# Altium schematic sheet POWER.SchDoc(POWER)
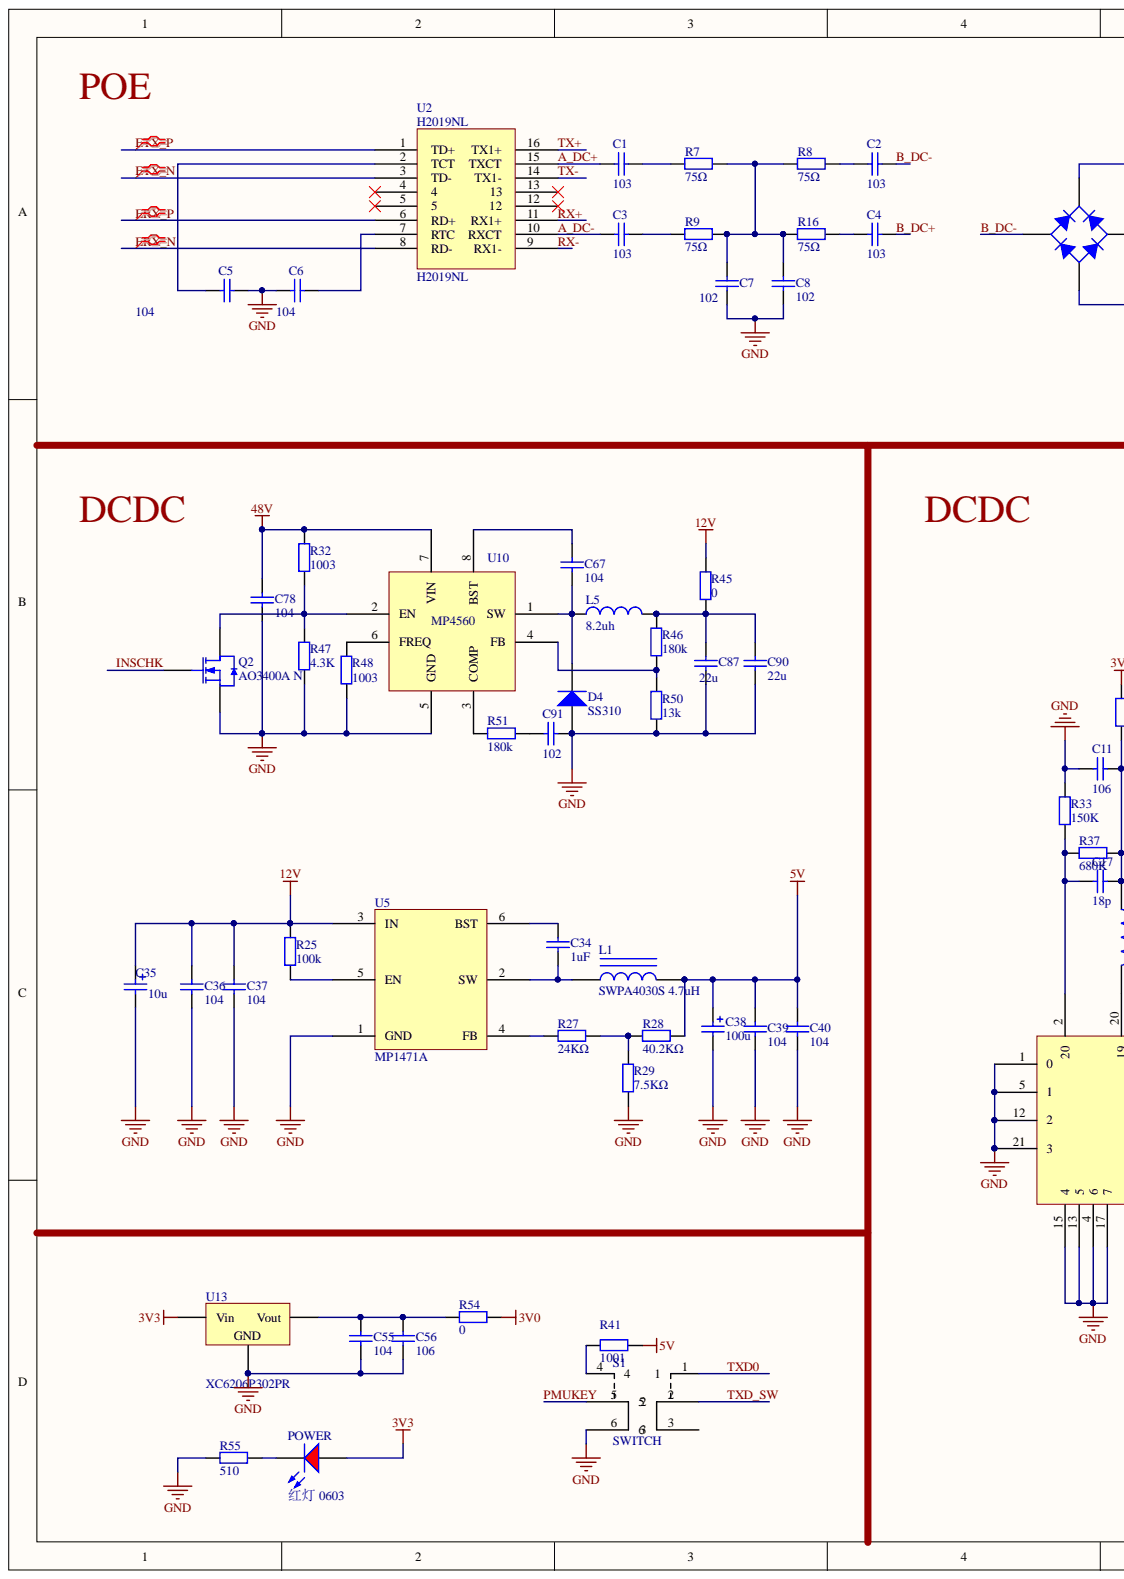
[diagram p0: POWER.SchDoc, left]
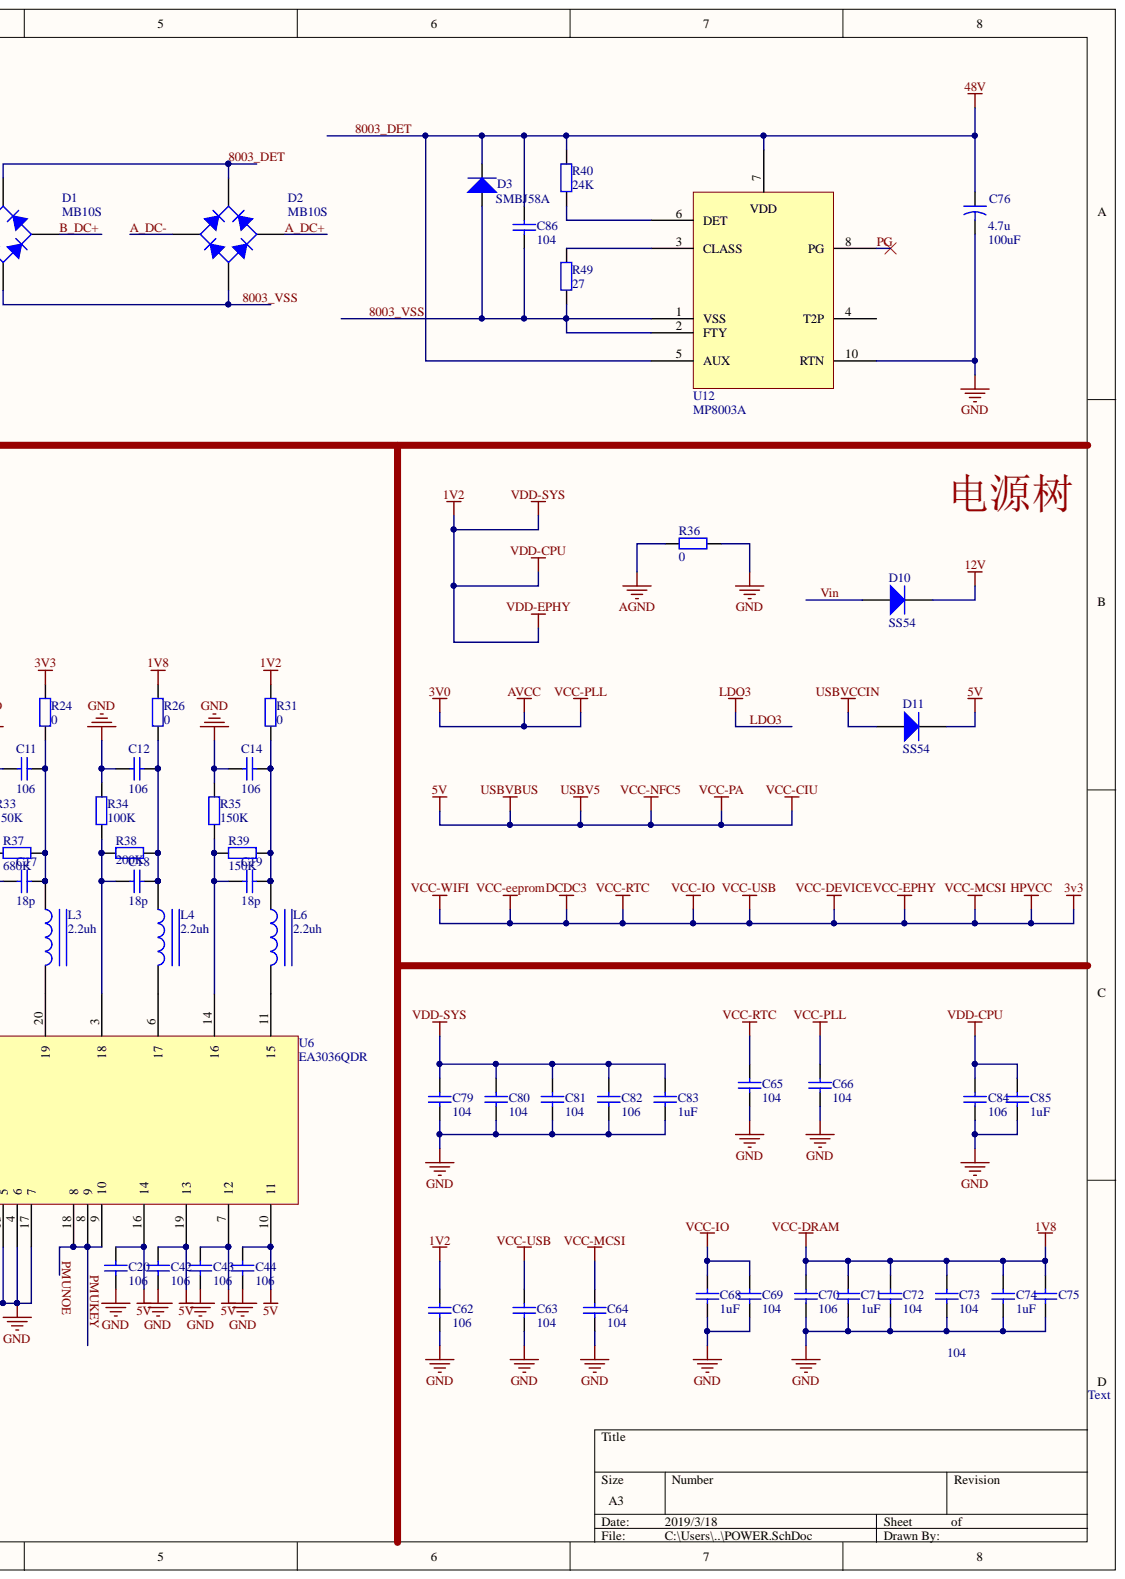
[diagram p1: POWER.SchDoc, right]

## Parts
C1  103  [0402_C]
C2  103  [0402_C]
C3  103  [0402_C]
C4  103  [0402_C]
C5  104  [0402_C]
C6  104  [0402_C]
C7  102  [0402_C]
C8  102  [0402_C]
C11  106  [0603_C]
C12  106  [0603_C]
C14  106  [0603_C]
C17  18p  [0402_C]
C18  18p  [0402_C]
C19  18p  [0402_C]
C20  106  [0603_C]
C34  1uF  [0402_C]
C35  10u  [SMD,4x5.4mm]
C36  104  [0402_C]
C37  104  [0402_C]
C38  100u  [SMD,5x5.4]
C39  104  [0402_C]
C40  104  [0402_C]
C42  106  [0603_C]
C43  106  [0603_C]
C44  106  [0603_C]
C55  104  [0402_C]
C56  106  [0603_C]
C62  106  [0402_C]
C63  104  [0402_C]
C64  104  [0402_C]
C65  104  [0402_C]
C66  104  [0402_C]
C67  104  [0402_C]
C68  1uF  [0402_C]
C69  104  [0402_C]
C70  106  [0402_C]
C71  1uF  [0402_C]
C72  104  [0402_C]
C73  104  [0402_C]
C74  1uF  [0402_C]
C75  104  [0402_C]  (value not drawn)
C76  4.7u  value 100uF  [CAPAE7066X54M]
C78  104  [1206_C]
C79  104  [0402_C]
C80  104  [0402_C]
C81  104  [0402_C]
C82  106  [0402_C]
C83  1uF  [0402_C]
C84  106  [0402_C]
C85  1uF  [0402_C]
C86  104  [C1206]
C87  22u  [0805_C]
C90  22u  [0805_C]
C91  102  [0402_C]
D1  MB10S  [MBS]
D2  MB10S  [MBS]
D3  SMBJ58A  [SMB(DO-214AA)_S1]
D4  SS310  [SMA(DO-214AC)_S1]
D10  SS54  [SMA(DO-214AC)_S1]
D11  SS54  [SMA(DO-214AC)_S1]
L1  SWPA4030S 4.7uH  [INDP4040X30N]
L3  2.2uh  [0806]
L4  2.2uh  [0806]
L5  value 8.2uh  [SWRH8040B]  (hidden comment: Inductor 8.2uh)
L6  2.2uh  [0806]
POWER  红灯 0603  Package: 0603  [0603_LED_S1]
Q2  AO3400A N  [SOT-23-3L]
R7  75Ω  [0402_R]
R8  75Ω  [0402_R]
R9  75Ω  [0402_R]
R16  75Ω  [0402_R]
R24  0  [0402_R]
R25  100k  [0402_R]
R26  0  [0402_R]
R27  24KΩ  [0402_R]
R28  40.2KΩ  [0603_R]
R29  7.5KΩ  [0402_R]
R31  0  [0402_R]
R32  1003  [0402_R]
R33  150K  [0402_R]
R34  100K  [0402_R]
R35  150K  [0402_R]
R36  0  [0402_R]
R37  680K  [0603_R]
R38  200K  [0402_R]
R39  150K  [0402_R]
R40  24K  [0402_R]
R41  1001  [0402_R]
R45  0  [0402_R]
R46  180k  [0603_R]
R47  4.3K  [0603_R]
R48  1003  [0402_R]
R49  27  [0603_R]
R50  13k  [0603_R]
R51  180k  [0603_R]
R54  0  [0402_R]
R55  510  [0402_R]
S1  SWITCH  [switch]
U2  H2019NL  [NetTrans]
U5  MP1471A  [SOT-23-6]
U6  EA3036QDR  [QFN20_3X3]
U10  MP4560  [LC-SOIC-8_EP_150mil]
U12  MP8003A  [QFN-10_3x3x05P]
U13  XC6206P302PR  [SOT-23-3L]

## Nets
1V2: C62.1 C79.2 C80.2 C81.2 C82.2 C83.2 C84.2 C85.2 R31.2
1V8: C70.2 C71.2 C72.2 C73.2 C74.2 C75.2 R26.2
3V0: C66.2 R54.1
3V3: C63.2 C64.2 C65.2 C68.2 C69.2 POWER.A R24.2 U13.3
5V: C20.1 C38.1 C39.2 C40.2 C42.1 C43.1 C44.1 D11.2 L1.2 R28.2 R41.2 U6.7 U6.10 U6.16 U6.19
12V: C35.1 C36.2 C37.2 D10.2 R25.2 R45.2 U5.3(IN)
AGND: R36.2
GND: C5.1 C6.2 C7.1 C8.1 C11.1 C12.1 C14.1 C20.2 C35.2 C36.1 C37.1 C38.2 C39.1 C40.1 C42.2 C43.2 C44.2 C55.1 C56.1 C62.2 C63.1 C64.1 C65.1 C66.1 C68.1 C69.1 C70.1 C71.1 C72.1 C73.1 C74.1 C75.1 C76.2 C78.1 C79.1 C80.1 C81.1 C82.1 C83.1 C84.1 C85.1 C87.1 C90.1 C91.2 D4.1 Q2.2 R29.1 R33.2 R34.1 R35.2 R36.1 R47.1 R48.1 R50.1 R55.1 S1.6 U5.1(GND) U6.1 U6.4 U6.5 U6.12 U6.13 U6.15 U6.17 U6.21 U10.5(GND) U12.10(RTN) U13.1
USBVCCIN: D11.1
8003_DET: C76.1 C78.2 C86.2 D1.2 D2.2 D3.2 R32.2 R40.2 U10.7(VIN) U12.5(AUX) U12.7
8003_VSS: C86.1 D1.4 D2.4 D3.1 R49.1 U12.1(VSS) U12.2(FTY)
A_DC+: C1.1 D2.3 U2.15
A_DC-: C3.1 D2.1 U2.10
B_DC+: C4.2 D1.3
B_DC-: C2.2 D1.1
ERX_N: U2.8
ERX_P: U2.6
ETX_N: U2.3
ETX_P: U2.1
INSCHK: Q2.1
PG: U12.8(PG)
PMUKEY: S1.5 U6.8 U6.9 U6.18
RX+: U2.11
RX-: U2.9
TX+: U2.16
TX-: U2.14
TXD0: S1.1
TXD_SW: S1.2
Vin: D10.1
NetC1_2: C1.2 R7.1
NetC2_1: C2.1 R8.2
NetC3_2: C3.2 R9.1
NetC4_1: C4.1 R16.2
NetC5_2: C5.2 U2.2
NetC6_1: C6.1 U2.7
NetC7_2: C7.2 C8.2 R7.2 R8.1 R9.2 R16.1
NetC11_2: C11.2 C17.2 L3.1 R24.1 R37.2
NetC12_2: C12.2 C18.2 L4.1 R26.1 R38.2
NetC14_2: C14.2 C19.2 L6.1 R31.1 R39.2
NetC17_1: C17.1 R33.1 R37.1 U6.2
NetC18_1: C18.1 R34.2 R38.1 U6.3
NetC19_1: C19.1 R35.1 R39.1 U6.14
NetC34_1: C34.1 L1.1 U5.2(SW)
NetC34_2: C34.2 U5.6(BST)
NetC55_2: C55.2 C56.2 R54.2 U13.2
NetC67_1: C67.1 D4.2 L5.1 U10.1(SW)
NetC67_2: C67.2 U10.8(BST)
NetC87_2: C87.2 C90.2 L5.2 R45.1 R46.2
NetC91_1: C91.1 R51.2
NetL3_2: L3.2 U6.20
NetL4_2: L4.2 U6.6
NetL6_2: L6.2 U6.11
NetPOWER_K: POWER.K R55.2
NetQ2_3: Q2.3 R32.1 R47.2 U10.2(EN)
NetR25_1: R25.1 U5.5(EN)
NetR27_1: R27.1 U5.4(FB)
NetR27_2: R27.2 R28.1 R29.2
NetR40_1: R40.1 U12.6(DET)
NetR41_1: R41.1 S1.4
NetR46_1: R46.1 R50.2 U10.4(FB)
NetR48_2: R48.2 U10.6(FREQ)
NetR49_2: R49.2 U12.3(CLASS)
NetR51_1: R51.1 U10.3(COMP)
LDO3:

Not connected: S1 3; U2 4 5 12 13; U12 4(T2P)

## Library data of the parts
C1-C4 = 0603 10nF (103) 10% 50V: 贴片电容; SuppliersPartNumber: C57112; Supplier: LC; Package: 0603; Library Name: Cap.SchLib; ComponentLink1URL: http://www.szlcsc.com/product/details_58143.html; ComponentLink1Description: 供应商链接; Component Kind: Standard
C5 C6 C36 C37 C39 C40 C63-C67 C69 C72 C73 C75 C79-C81 = 0603 100nF (104) 10% 50V: 贴片电容; SuppliersPartNumber: C14663; Supplier: LC; Package: 0603; Library Name: Cap.SchLib; ComponentLink1URL: http://www.szlcsc.com/product/details_15331.html; ComponentLink1Description: 供应商链接; Component Kind: Standard
C7 C8 C91 = 0603 1nF (102) 10% 50V: 贴片电容; SuppliersPartNumber: C1588; Supplier: LC; Package: 0603; Library Name: Cap.SchLib; ComponentLink1URL: http://www.szlcsc.com/product/details_1940.html; ComponentLink1Description: 供应商链接; Component Kind: Standard
C11 C12 C14 C20 C42-C44 C56 C62 C70 C82 C84 = 0603 10uF (106) 10% 10V: 贴片电容; SuppliersPartNumber: C19702; Supplier: LC; Package: 0603; ComponentLink1URL: http://www.szlcsc.com/product/details_20411.html; ComponentLink1Description: 供应商链接; Component Kind: Standard
  C11 C12 C14 C20 C42-C44 C56: Library Name: Miscellaneous Devices LC.IntLib
  C62 C70 C82 C84: Library Name: Cap.SchLib
C17-C19 = 0402 18pF (180) 5% 50V: 贴片电容; SuppliersPartNumber: C1549; Supplier: LC; Package: 0402; Library Name: Miscellaneous Devices LC.IntLib; ComponentLink1URL: http://www.szlcsc.com/product/details_1901.html; ComponentLink1Description: 供应商链接; Component Kind: Standard
C34 C68 C71 C74 C83 C85 = 0603 1uF (105) 10% 50V: 贴片电容; SuppliersPartNumber: C15849; Supplier: LC; Package: 0603; Library Name: Cap.SchLib; ComponentLink1URL: http://www.szlcsc.com/product/details_16531.html; ComponentLink1Description: 供应商链接; Component Kind: Standard
C35 = 3216 10uF (106) 10% 16V: 贴片点解电容 CS1C100M-CRC54; SuppliersPartNumber: C7171; Supplier: LC; Package: 3216; Library Name: Cap.SchLib; ComponentLink1URL: http://www.szlcsc.com/product/details_7643.html; ComponentLink1Description: 供应商链接; Component Kind: Standard
C38 = 3528 100uF (107) 10% 6.3V: 贴片点解电容 CS0J101M-CRD54; SuppliersPartNumber: C16133; Supplier: LC; Package: 3528; Library Name: Cap.SchLib; ComponentLink1URL: http://www.szlcsc.com/product/details_16815.html; ComponentLink1Description: 供应商链接; Component Kind: Standard
C55 = 0402 100nF (104) 10% 16V: 贴片电容; SuppliersPartNumber: C1525; Supplier: LC; Package: 0402; Library Name: Miscellaneous Devices LC.IntLib; ComponentLink1URL: http://www.szlcsc.com/product/details_1877.html; ComponentLink1Description: 供应商链接; Component Kind: Standard
C76 = Cap2: 直插电解电容; Simulation: CAP; Signal Integrity: Cap; Published: 23-Sep-2002; PackageReference: CAPR5-4X5; PackageDescription: Capacitor; 2 Leads; Library Name: Miscellaneous Devices.IntLib; Component Kind: Standard; Publisher: Altium Limited
C78 = 1206 100nF (104) 10% 50V: 贴片电容; SuppliersPartNumber: C24497; Supplier: LC; Package: 1206; Library Name: Cap.SchLib; ComponentLink1URL: http://www.szlcsc.com/product/details_25237.html; ComponentLink1Description: 供应商链接; Component Kind: Standard
C86 = Cap Semi: Capacitor (Semiconductor SIM Model); Value: 0.1uF; Simulation: CAP; Signal Integrity: Cap Semi; Published: 8-Jun-2000; PackageReference: C1206; PackageDescription: Chip Capacitor; Library Name: Miscellaneous Devices.IntLib; LatestRevisionNote: IPC-7351 Footprint Added.; LatestRevisionDate: 29-May-2009; Component Kind: Standard; Publisher: Altium Limited
C87 C90 = 0805 22uF (226) 20% 25V: 贴片电容; SuppliersPartNumber: C45783; Supplier: LC; Package: 0805; Library Name: Cap.SchLib; ComponentLink1URL: http://www.szlcsc.com/product/details_46786.html; ComponentLink1Description: 供应商链接; Component Kind: Standard
D1 D2 = MBS MB10S: 整流桥; SuppliersPartNumber: C2488; Supplier: LC; Package: MBS; Library Name: Miscellaneous Devices LC.IntLib; ComponentLink1URL: http://www.szlcsc.com/product/details_2865.html; ComponentLink1Description: 供应商链接; Component Kind: Standard
D3 = TVS: Type: SMBJ58A; Published: 8-Jun-2000; PackageReference: SMC; PackageDocument: Sep-1996; LatestRevisionNote: Re-released for DXP Platform.; LatestRevisionDate: 17-Jul-2002; Description: TVS; Component Kind: Standard; Code_JEDEC: DO-214-AB; Publisher: Altium Limited; Library Name: 主集成库.IntLib
D4 = SMA(DO-214AC) SS210: 二极管; SuppliersPartNumber: C14996; Supplier: LC; Package: SMA(DO-214AC); Library Name: Miscellaneous Devices LC.IntLib; ComponentLink1URL: http://www.szlcsc.com/product/details_15669.html; ComponentLink1Description: 供应商链接; Component Kind: Standard
D10 D11 = SMA(DO-214AC) SS54: 二极管; SuppliersPartNumber: C22452; Supplier: LC; Package: SMA(DO-214AC); Library Name: Miscellaneous Devices LC.IntLib; ComponentLink1URL: http://www.szlcsc.com/product/details_23178.html; ComponentLink1Description: 供应商链接; Component Kind: Standard
L1 = SWPA4030S: SWPA4030S Inductor; LC: C57269; Component Kind: Standard; Library Name: Schlib_FFS.SchLib
L3 = 0805 2.2uH 10%: 贴片电感; SuppliersPartNumber: C1043; Supplier: LC; Package: 0805; Library Name: Miscellaneous Devices LC.IntLib; ComponentLink1URL: http://www.szlcsc.com/product/details_1395.html; ComponentLink1Description: 供应商链接; Component Kind: Standard
L4 = 0805 2.2uH 10%: C307626 贴片电感; SuppliersPartNumber: C1043; Supplier: LC; Package: 0805; Library Name: Miscellaneous Devices LC.IntLib; ComponentLink1URL: http://www.szlcsc.com/product/details_1395.html; ComponentLink1Description: 供应商链接; Component Kind: Standard
L5 = Inductor: SWPA8040S1R0NT; Code_IEC: 1005; Simulation: INDUCTOR; Signal Integrity: Inductor; Published: 8-Jun-2000; PackageReference: 0402-A; PackageDescription: Chip Inductor; Library Name: Miscellaneous Devices.IntLib; LatestRevisionNote: IPC-7351 Footprint Added.; LatestRevisionDate: 29-May-2009; Component Kind: Standard; Code_JEITA: 0402; Publisher: Altium Limited
L6 = 0805 2.2uH 10%: 贴片功率电感; SuppliersPartNumber: C1043; Supplier: LC; Package: 0805; Library Name: Miscellaneous Devices LC.IntLib; ComponentLink1URL: http://www.szlcsc.com/product/details_1395.html; ComponentLink1Description: 供应商链接; Component Kind: Standard
POWER = 0603 红灯: 发光二极管; SuppliersPartNumber: C2286; Supplier: LC; Library Name: Miscellaneous Devices LC.IntLib; ComponentLink1URL: http://www.szlcsc.com/product/details_2659.html; ComponentLink1Description: 供应商链接; Component Kind: Standard
Q2 = AO3400A N道沟 X0YV1T SOT-23: MOS(场效应管); SuppliersPartNumber: C20917; Supplier: LC; Package: SOT-23; Library Name: Miscellaneous Devices LC.IntLib; ComponentLink1URL: http://www.szlcsc.com/product/details_21629.html; ComponentLink1Description: 供应商链接; Component Kind: Standard
R7-R9 R16 = 0603 75Ω (75R0) 1%: 贴片电阻; SuppliersPartNumber: C4275; Supplier: LC; Package: 0603; Library Name: RES.SchLib; ComponentLink1URL: http://www.szlcsc.com/product/details_4682.html; ComponentLink1Description: 供应商链接; Component Kind: Standard
R24 R26 R31 R45 R54 = 0805 0Ω (0R0) 1%: 贴片电阻; SuppliersPartNumber: C17477; Supplier: LC; Package: 0805; Library Name: RES.SchLib; ComponentLink1URL: http://www.szlcsc.com/product/details_18165.html; ComponentLink1Description: 供应商链接; Component Kind: Standard
R25 R32 R48 = 0603 100KΩ (1003) 1%: 贴片电阻; SuppliersPartNumber: C25803; Supplier: LC; Package: 0603; Library Name: RES.SchLib; ComponentLink1URL: http://www.szlcsc.com/product/details_26546.html; ComponentLink1Description: 供应商链接; Component Kind: Standard
R27 R40 = 0603 24KΩ (2402) 1%: 贴片电阻; SuppliersPartNumber: C23352; Supplier: LC; Package: 0603; Library Name: RES.SchLib; ComponentLink1URL: http://www.szlcsc.com/product/details_24085.html; ComponentLink1Description: 供应商链接; Component Kind: Standard
R28 = 0603 40.2KΩ (4022) 1%: 贴片电阻; SuppliersPartNumber: C12447; Supplier: LC; Package: 0603; Library Name: RES.SchLib; ComponentLink1URL: http://www.szlcsc.com/product/details_13081.html; ComponentLink1Description: 供应商链接; Component Kind: Standard
R29 = 0603 7.5KΩ (7501) 1%: 贴片电阻; SuppliersPartNumber: C23234; Supplier: LC; Package: 0603; Library Name: RES.SchLib; ComponentLink1URL: http://www.szlcsc.com/product/details_23961.html; ComponentLink1Description: 供应商链接; Component Kind: Standard
R33 R35 R39 = 0402 150KΩ (1503) 1%: 贴片电阻; SuppliersPartNumber: C25755; Supplier: LC; Package: 0402; Library Name: Miscellaneous Devices LC.IntLib; ComponentLink1URL: http://www.szlcsc.com/product/details_26498.html; ComponentLink1Description: 供应商链接; Component Kind: Standard
R34 = 0402 100KΩ (1003) 1%: 贴片电阻; SuppliersPartNumber: C25741; Supplier: LC; Package: 0402; Library Name: Miscellaneous Devices LC.IntLib; ComponentLink1URL: http://www.szlcsc.com/product/details_26484.html; ComponentLink1Description: 供应商链接; Component Kind: Standard
R36 = 0603 0Ω (0R0) 1%: 贴片电阻; SuppliersPartNumber: C21189; Supplier: LC; Package: 0603; Library Name: RES.SchLib; ComponentLink1URL: http://www.szlcsc.com/product/details_21903.html; ComponentLink1Description: 供应商链接; Component Kind: Standard
R37 = 0603 680KΩ (6803) 1%: 贴片电阻; SuppliersPartNumber: C25822; Supplier: LC; Package: 0603; Library Name: Miscellaneous Devices LC.IntLib; ComponentLink1URL: http://www.szlcsc.com/product/details_26565.html; ComponentLink1Description: 供应商链接; Component Kind: Standard
R38 = 0402 200KΩ (2003) 1%: 贴片电阻; SuppliersPartNumber: C25764; Supplier: LC; Package: 0402; Library Name: Miscellaneous Devices LC.IntLib; ComponentLink1URL: http://www.szlcsc.com/product/details_26507.html; ComponentLink1Description: 供应商链接; Component Kind: Standard
R41 = 0402 1KΩ (1001) 1%: 贴片电阻; SuppliersPartNumber: C11702; Supplier: LC; Package: 0402; Library Name: Miscellaneous Devices LC.IntLib; ComponentLink1URL: http://www.szlcsc.com/product/details_12256.html; ComponentLink1Description: 供应商链接; Component Kind: Standard
R46 R51 = 0603 180KΩ (1803) 1%: 贴片电阻; SuppliersPartNumber: C22827; Supplier: LC; Package: 0603; Library Name: RES.SchLib; ComponentLink1URL: http://www.szlcsc.com/product/details_23554.html; ComponentLink1Description: 供应商链接; Component Kind: Standard
R47 = 0603 4.3KΩ (4301) 1%: 贴片电阻; SuppliersPartNumber: C23159; Supplier: LC; Package: 0603; Library Name: RES.SchLib; ComponentLink1URL: http://www.szlcsc.com/product/details_23886.html; ComponentLink1Description: 供应商链接; Component Kind: Standard
R49 = 0603 27Ω (27R0) 1%: 贴片电阻; SuppliersPartNumber: C25190; Supplier: LC; Package: 0603; Library Name: RES.SchLib; ComponentLink1URL: http://www.szlcsc.com/product/details_25933.html; ComponentLink1Description: 供应商链接; Component Kind: Standard
R50 = 0603 13KΩ (1302) 1%: 贴片电阻; SuppliersPartNumber: C22797; Supplier: LC; Package: 0603; Library Name: RES.SchLib; ComponentLink1URL: http://www.szlcsc.com/product/details_23524.html; ComponentLink1Description: 供应商链接; Component Kind: Standard
R55 = 0402 510Ω (5100) 1%: 贴片电阻; SuppliersPartNumber: C25123; Supplier: LC; Package: 0402; Library Name: Miscellaneous Devices LC.IntLib; ComponentLink1URL: http://www.szlcsc.com/product/details_25866.html; ComponentLink1Description: 供应商链接; Component Kind: Standard
S1 = SWITCH: C194404; Library Name: V3SDV.SchLib; Component Kind: Standard
U2 = H2019NL: C110250 网络变压器; Library Name: PCB_Project_2.IntLib; Component Kind: Standard
U5 = MP1471A: High-Efficiency, 3A 16V, 500kHz Synchronous, Step-Down Converter In a 6-Pin TSOT 23; LC: C58565; Component Kind: Standard; Library Name: Schlib_FFS.SchLib
U6 = EA3036: EA3036QDR  三路电源芯片; LC: C111587; Component Kind: Standard; Library Name: Schlib_FFS.SchLib
U10 = MP4560: 2A, 2MHz, 55V Step-Down Converter; Library Name: Schlib1.SchLib; Component Kind: Standard
U12 = MP8003A: IEEE 802.3af/at, PoE, Powered Device, Interface Controller; SuppliersPartNumber: C192096; Supplier: LC; Library Name: Schlib.SchLib; Component Kind: Standard
U13 = SOT-23 XC6206P332MR: C9972 低压差线性稳压(LDO)XC6206P302MR; SuppliersPartNumber: C5446; Supplier: LC; Package: SOT-23; Library Name: Miscellaneous Devices LC.IntLib; ComponentLink1URL: http://www.szlcsc.com/product/details_5896.html; ComponentLink1Description: 供应商链接; Component Kind: Standard
